# Altium schematic sheet Charge.SchDoc
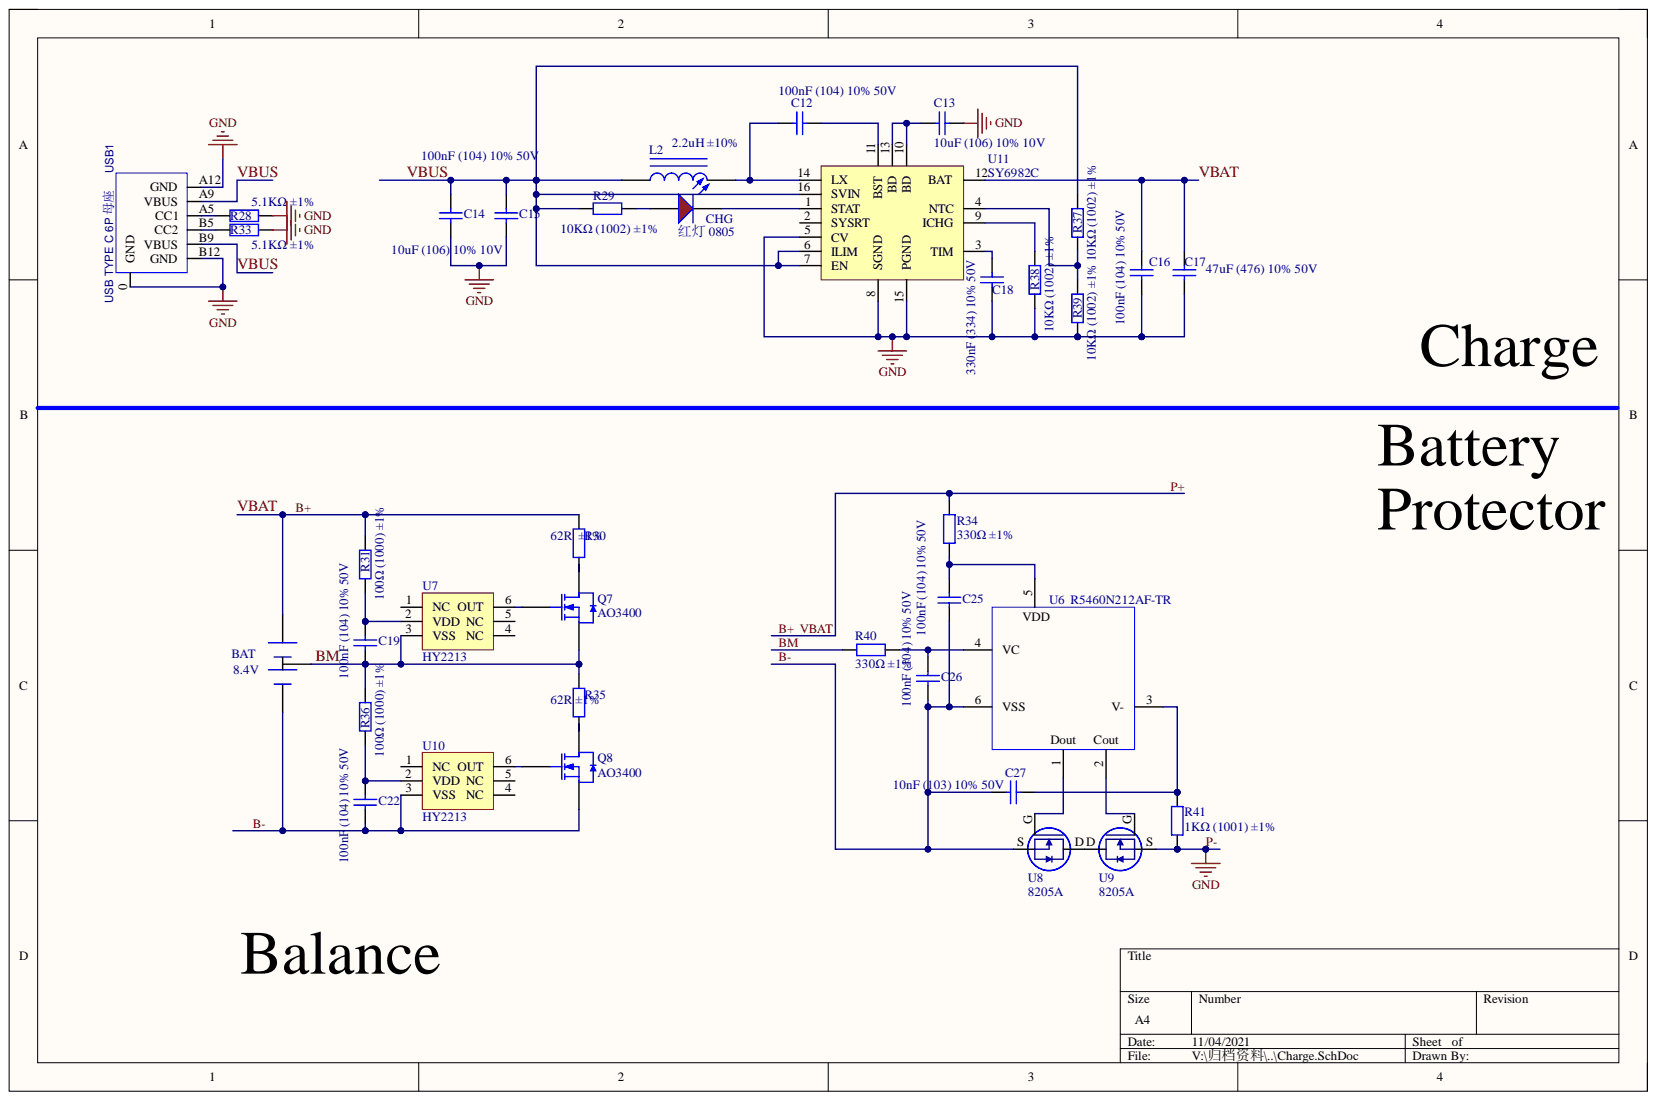
[diagram p0: Charge.SchDoc]

## Parts
BAT  8.4V  [18650-锂电池座-双节-贴片]
C12  100nF (104) 10% 50V  [电容-0603]
C13  10uF (106) 10% 10V  [电容-0603]
C14  10uF (106) 10% 10V  [电容-0603]
C15  100nF (104) 10% 50V  [电容-0603]
C16  100nF (104) 10% 50V  [电容-0603]
C17  47uF (476) 10% 50V  [电容-1206]
C18  330nF (334) 10% 50V  [0603_C]
C19  100nF (104) 10% 50V  [电容-0603]
C22  100nF (104) 10% 50V  [电容-0603]
C25  100nF (104) 10% 50V  [电容-0603]
C26  100nF (104) 10% 50V  [电容-0603]
C27  10nF (103) 10% 50V  [电容-0603]
CHG  红灯 0805  Package: 0805  [0805_LED_S1]
L2  2.2uH ±10%  [CD54]
Q7  AO3400  [SOT23-3]
Q8  AO3400  [SOT23-3]
R28  5.1KΩ ±1%  [电阻_0603]
R29  10KΩ (1002) ±1%  [电阻_0603]
R30  62R  ±1%  [电阻_2512]
R31  100Ω (1000) ±1%  [电阻_0603]
R33  5.1KΩ ±1%  [电阻_0603]
R34  330Ω ±1%  [电阻_0603]
R35  62R ±1%  [电阻_2512]
R36  100Ω (1000) ±1%  [电阻_0603]
R37  10KΩ (1002) ±1%  [电阻_0603]
R38  10KΩ (1002) ±1%  [电阻_0603]
R39  10KΩ (1002) ±1%  [电阻_0603]
R40  330Ω ±1%  [电阻_0603]
R41  1KΩ (1001) ±1%  [电阻_0603]
U6  R5460N212AF-TR  [SOT23-6]
U7  HY2213  [SOT23-6]
U8  8205A  [8205A]
U9  8205A  [8205A]
U10  HY2213  [SOT23-6]
U11  SY6982C  [QFN3*3]
USB1  USB TYPE C 6P 母座  [USB-TYPE-C-母座-6PIN]

## Nets
B+: BAT.1 C16.2 C17.2 R30.2 R31.2 R34.2 U11.12(BAT)
B-: BAT.2 C22.1 C25.1 C26.1 C27.2 Q8.2 U6.6(VSS) U8.S U10.3(VSS)
BM: BAT.3 C19.1 Q7.2 R35.2 R36.2 R40.1 U7.3(VSS)
P-: C13.1 C14.1 C15.1 C16.1 C17.1 C18.1 R28.2 R33.2 R38.1 R39.1 R41.1 U9.S U11.5(CV) U11.8(SGND) U11.15(PGND) USB1.0(GND) USB1.A12(GND) USB1.B12(GND)
VBUS: C14.2 C15.2 L2.1 R29.1 R37.2 U11.6(ILIM) U11.7(EN) U11.16(SVIN) USB1.A9(VBUS) USB1.B9(VBUS)
NetC12_1: C12.1 L2.2 U11.14(LX)
NetC12_2: C12.2 U11.11(BST)
NetC13_2: C13.2 U11.10(BD) U11.13(BD)
NetC18_2: C18.2 U11.3(TIM)
NetC19_2: C19.2 R31.1 U7.2(VDD)
NetC22_2: C22.2 R36.1 U10.2(VDD)
NetC25_2: C25.2 R34.1 U6.5
NetC26_2: C26.2 R40.2 U6.4(VC)
NetC27_1: C27.1 R41.2 U6.3(V-)
NetCHG_A: CHG.A R29.2
NetCHG_K: CHG.K U11.1(STAT)
NetQ7_1: Q7.1 U7.6(OUT)
NetQ7_3: Q7.3 R30.1
NetQ8_1: Q8.1 U10.6(OUT)
NetQ8_3: Q8.3 R35.1
NetR28_1: R28.1 USB1.A5(CC1)
NetR33_1: R33.1 USB1.B5(CC2)
NetR37_1: R37.1 R39.2 U11.4(NTC)
NetR38_2: R38.2 U11.9(ICHG)
NetU6_1: U6.1 U8.G
NetU6_2: U6.2 U9.G
NetU8_D: U8.D U9.D

Not connected: U7 1(NC) 4(NC) 5(NC); U10 1(NC) 4(NC) 5(NC); U11 2(SYSRT)

## Library data of the parts
BAT = 2x18650: 2节18650电池盒; Component Kind: Standard; LatestRevisionDate: 17-Jul-2002; LatestRevisionNote: Re-released for DXP Platform.; Library Name: Power Board.SchLib; PackageDescription: Battery; 2 Leads; PackageReference: BAT-2; Published: 24-Mar-1999; Publisher: Altium Limited
C12 C15 C16 C19 C22 = 0603 100nF (104) 10% 50V: 贴片电容; Component Kind: Standard; ComponentLink1Description: 供应商链接; ComponentLink1URL: http://www.szlcsc.com/product/details_15331.html; Library Name: Miscellaneous Devices LC.IntLib; Package: 0603; Supplier: LC; SuppliersPartNumber: C14663
C13 C14 C25-C27 = 0603 10uF (106) 10% 10V: 贴片电容; Component Kind: Standard; ComponentLink1Description: 供应商链接; ComponentLink1URL: http://www.szlcsc.com/product/details_20411.html; Library Name: Miscellaneous Devices LC.IntLib; Package: 0603; Supplier: LC; SuppliersPartNumber: C19702
C17 = 1206 10uF (106) 10% 50V: 贴片电容; Component Kind: Standard; ComponentLink1Description: 供应商链接; ComponentLink1URL: http://www.szlcsc.com/product/details_14236.html; Library Name: Miscellaneous Devices LC.IntLib; Package: 1206; Supplier: LC; SuppliersPartNumber: C13585
C18 = 330nF (334) 10% 50V: 贴片电容; Component Kind: Standard; ComponentLink1Description: 供应商链接; ComponentLink1URL: http://www.szlcsc.com/product/details_20411.html; Library Name: Power Board.SchLib; Package: 0603; Supplier: LC; SuppliersPartNumber: C19702
CHG = 0805 红灯: 发光二极管; Component Kind: Standard; ComponentLink1Description: 供应商链接; ComponentLink1URL: http://www.szlcsc.com/product/details_2668.html; Library Name: Miscellaneous Devices LC.IntLib; Supplier: LC; SuppliersPartNumber: C2295
L2 = 0805 2.2uH 10%: 贴片电感; Component Kind: Standard; ComponentLink1Description: 供应商链接; ComponentLink1URL: http://www.szlcsc.com/product/details_1395.html; Library Name: Miscellaneous Devices LC.IntLib; Package: 0805; Supplier: LC; SuppliersPartNumber: C1043
Q7 Q8 = AO3400A N道沟 X0YV1T SOT-23: MOS(场效应管); Component Kind: Standard; ComponentLink1Description: 供应商链接; ComponentLink1URL: http://www.szlcsc.com/product/details_21629.html; Library Name: Miscellaneous Devices LC.IntLib; Package: SOT-23; Supplier: LC; SuppliersPartNumber: C20917
R28 R29 R33 R37-R39 = 0603 10KΩ (1002) 1%: 贴片电阻; Component Kind: Standard; ComponentLink1Description: 供应商链接; ComponentLink1URL: http://www.szlcsc.com/product/details_26547.html; Library Name: Miscellaneous Devices LC.IntLib; Package: 0603; Supplier: LC; SuppliersPartNumber: C25804
R30 R35 = 1206 100Ω (1000) 1%: 贴片电阻; Component Kind: Standard; ComponentLink1Description: 供应商链接; ComponentLink1URL: http://www.szlcsc.com/product/details_18589.html; Library Name: Miscellaneous Devices LC.IntLib; Package: 1206; Supplier: LC; SuppliersPartNumber: C17901
R31 R36 = 0603 100Ω (1000) 1%: 贴片电阻; Component Kind: Standard; ComponentLink1Description: 供应商链接; ComponentLink1URL: http://www.szlcsc.com/product/details_23502.html; Library Name: Miscellaneous Devices LC.IntLib; Package: 0603; Supplier: LC; SuppliersPartNumber: C22775
R34 R40 R41 = R: 贴片电阻; 封装库来自淘宝卖家ID：黄晶宝石: 其他店铺购入均为盗版假冒封装库，请立即申请退款; 联系卖家，免费查找封装: 唯一出售店铺网址https://shop463601444.taobao.com; BOM名称: 贴片电阻; Component Kind: Standard; Library Name: 0.1 - 电阻-电容-电感-磁珠-电位器.SchLib
U6 = R5460N212AF: R5460N212AF-TR 双锂电池保护芯片; Component Kind: Standard; Library Name: SunFounder_Library.IntLib
U8 U9 = 8205A: 8205A 锂电池保护芯片; Component Kind: Standard; Library Name: SunFounder_Library.IntLib
USB1 = USB TYPE C 6P 母座: 联系卖家 免费查找封装: 唯一出售店铺网址https://shop463601444.taobao.com; Component Kind: Standard; Description: USB TYPE C 6P 母座; Library Name: SunFounder_Library.IntLib
U7 U10 = HY2213: Component Kind: Standard; Library Name: Power Board.SchLib
  U7: Description: HY2213
U11 = SY6982C: Component Kind: Standard; Description: SY6982C; Library Name: Power Board.SchLib
